# Altium schematic sheet 04-Accel.SchDoc
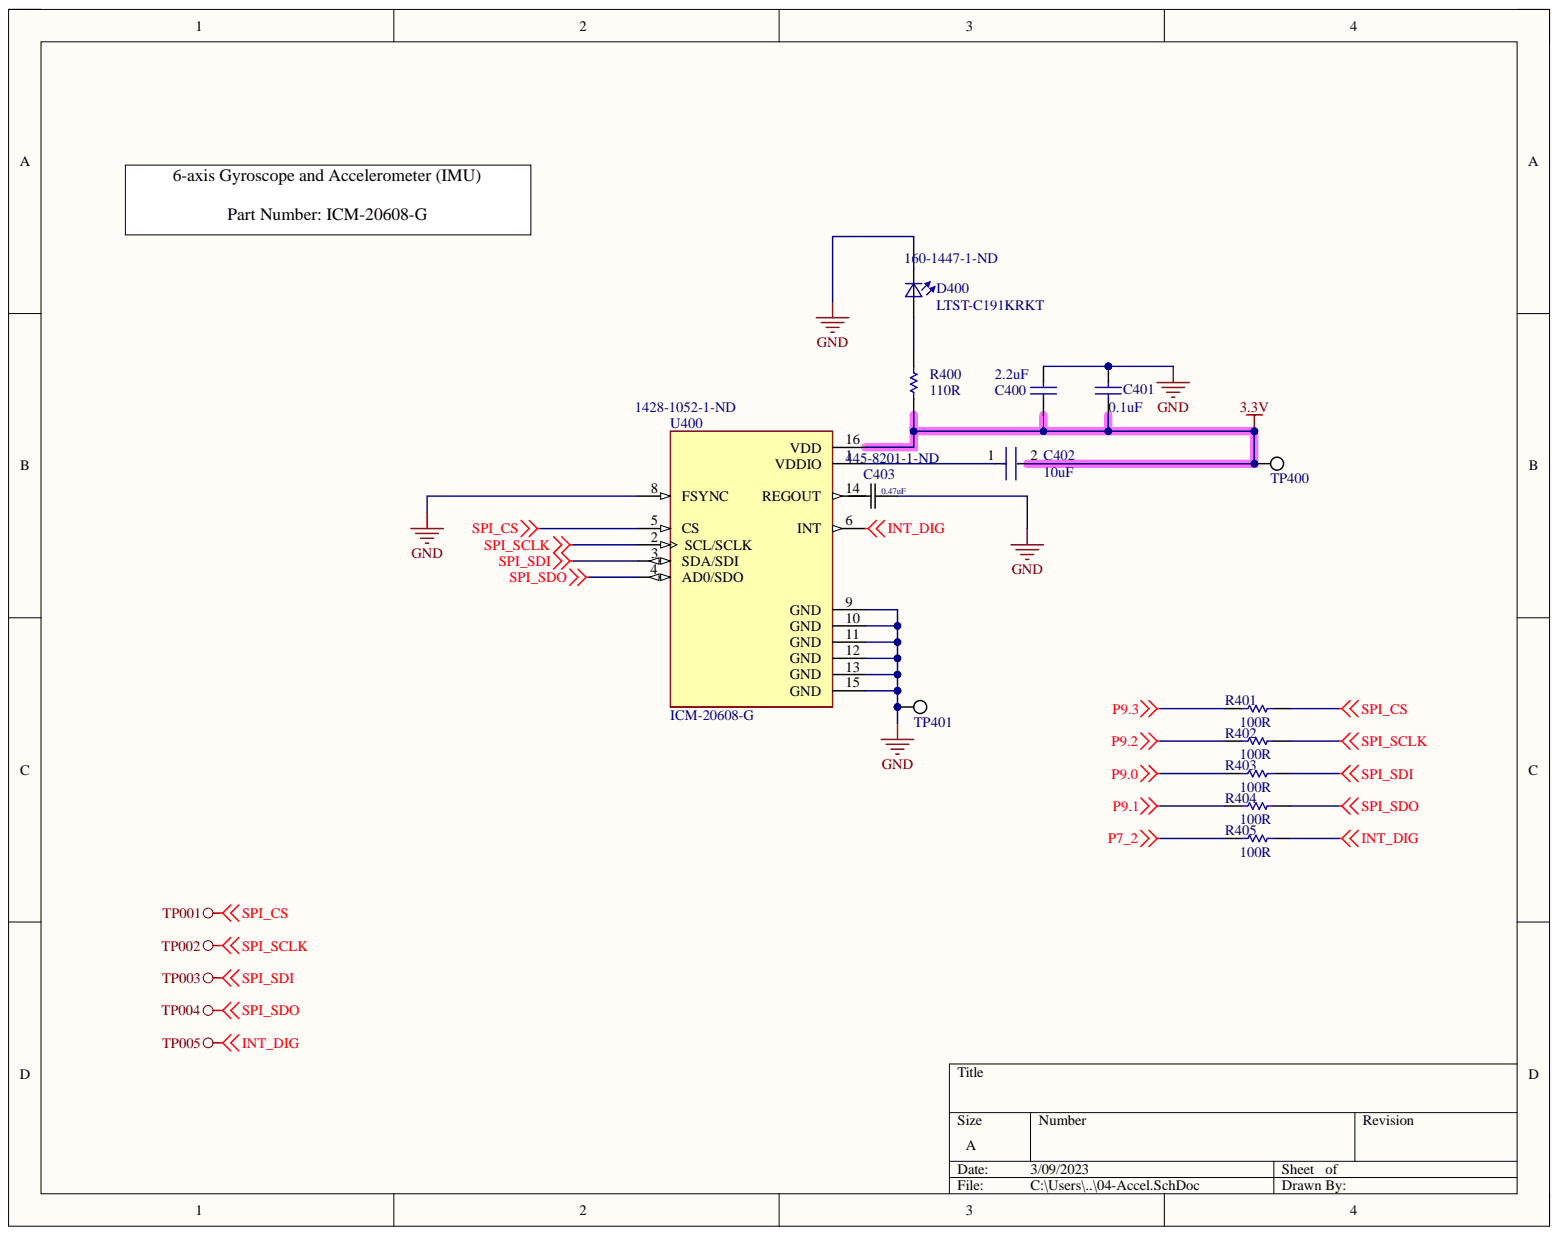
[diagram p0: 04-Accel.SchDoc]

## Parts
C400  2.2uF  [CAPC2013X145X50ML20T25]
C401  0.1uF  [CAPC2013X145X50LL20T25]
C402  10uF  [FP-C0805C-DN-MFG]
C403  0.47uF  Supplier Part Number 2: 445-8201-1-ND  [CAP_2012_TDK]
D400  LTST-C191KRKT  Supplier Part Number 1: 160-1447-1-ND  [0603]
R400  110R  [RESC2013X60X40NL20T25]
R401  100R  [RESC2013X60X40ML20T25]
R402  100R  [RESC2013X60X40ML20T25]
R403  100R  [RESC2013X60X40ML20T25]
R404  100R  [RESC2013X60X40ML20T25]
R405  100R  [RESC2013X60X40ML20T25]
TP400  5019  [KSTN-5019_V]  (value not drawn)
TP401  5019  [KSTN-5019_V]  (value not drawn)
U400  ICM-20608-G  Supplier Part Number 1: 1428-1052-1-ND  [PQFN50P300X300X80-16N]

## Nets
3.3V: C400.1 C401.1 C402.2 R400.1 TP400.1 U400.16(VDD)
GND: C400.2 C401.2 C403.2 D400.1 TP401.1 U400.8(FSYNC) U400.9(GND) U400.10(GND) U400.11(GND) U400.12(GND) U400.13(GND) U400.15(GND)
INT_DIG: R405.2 U400.6(INT)
P7_2: R405.1
P9.0: R403.1
P9.1: R404.1
P9.2: R402.1
P9.3: R401.1
SPI_CS: R401.2 U400.5(CS)
SPI_SCLK: R402.2 U400.2(SCL/SCLK)
SPI_SDI: R403.2 U400.3(SDA/SDI)
SPI_SDO: R404.2 U400.4(AD0/SDO)
NetC402_1: C402.1 U400.1(VDDIO)
NetD400_2: D400.2 R400.2

Not connected: C403 1; U400 14(REGOUT)

## Library data of the parts
C400 = CMP-1036-04819-2: Manufacturer: Kemet; Part Number: C0805C225K9PACTU; Component Kind: Standard; Library Name: Altium Content Vault
C401 = CMP-1036-04391-2: Manufacturer: Kemet; Part Number: C0805C104K9RACTU; Component Kind: Standard; Library Name: Altium Content Vault
C402 = CMP-1036-03808-3: CAP CER 10000PF 10V X7R 0805; Manufacturer: KEMET; Manufacturer Part Number: C0805C103K8RACTU; Capacitance: 10,000 pF; Material: Ceramic; Temperature Coefficient: 30ppm/°C; Tolerance: 0.1; Value: 10,000 pF; Automotive: No; Category: Cap; Component Kind: Standard; ComponentLink2Description: Datasheet; ComponentLink2URL: https://content.kemet.com/datasheets/KEM_C1003_C0G_SMD.pdf; Device Class L1: Passive Components; Device Class L2: Capacitors; Device Class L3: Ceramic Capacitors; Digikey Description: CAP CER 10000PF 10V X7R 0805; Height: 0.88mm; Ipc Land Pattern Name: CAPC200125X78; Lead Free: Yes; Library Name: Altium Content Vault; Mouser Description: Multilayer Ceramic Capacitors MLCC - SMD/SMT 10volts 10000pF X7R 10%; Octopart: https://octopart.com/c0805c103k8ractu-kemet-12595572; Package: C0805C-DN; RoHS: TRUE; Temperature: -55°C to +125°C; Temperature Characteristic: X7R; Temperature Range High: +125°C; Temperature Range Low: -55°C; Voltage Rating: 10 V
C403 = CGJ4J2X7R0J474K125AA: Cap Ceramic 0.47uF 6.3V X7R 10% Pad SMD 0805 125C Automotive T/R; Manufacturer: TDK; Capacitance: 470nF; Ratings: COTS; Case Code (Imperial): 0805; Case Code (Metric): 2012; Case/Package: 0805; Category: Ceramic Capacitors; Component Kind: Standard; ComponentLink1Description: https://datasheet.ciiva.com/15192/getdatasheetpartid-712880-15192524.pdf?src-supplier=Verical; ComponentLink1URL: https://datasheet.ciiva.com/15192/getdatasheetpartid-712880-15192524.pdf?src-supplier=Verical; ComponentLink2Description: https://datasheet.ciiva.com/24216/mlcc-highreliability-general-en-520187-24216918.pdf?src-supplier=Mouser; ComponentLink2URL: https://datasheet.ciiva.com/24216/mlcc-highreliability-general-en-520187-24216918.pdf?src-supplier=Mouser; Depth: 1.25mm; Dielectric: X7R; Height: 1.25mm; Length: 2mm; Library Name: CGJ4J2X7R0J474K125AA.SchLib; Manufacturer Part Number: CGJ4J2X7R0J474K125AA; Max Operating Temperature: 125°C; Mfr_Name: TDK; Min Operating Temperature: -55°C; Mount: Surface Mount; Package Quantity: 2000; Packaging: Tape and Reel; Pricing 2: 1=0.43000, 10=0.30400, 50=0.23020, 100=0.20040, 500=0.14848, 1000=0.12620 (USD); Radiation Hardening: No; Resistor Type: Ceramic; RoHS: Yes; Series: CGJ; Stock 2: 1790; Supplier 1: Digi-Key; Supplier 2: Digi-Key; Termination: SMD/SMT; Thickness: 0.053inch; Tolerance: 10%; Voltage Rating: 6.3V; Voltage Rating (DC): 6.3V; Weight: 0.000194oz; Width: 0.049inch
D400 = LTST-C191KRKT: LED Uni-Color Red 639nm 2-Pin Chip LED T/R / LED RED CLEAR 0603 SMD; Manufacturer: Vishay Lite-On; Dominant Wavelength: 631nm; Forward Current: 30mA; Forward Voltage: 2V; Lens Color: Colorless; Lens Style: Clear,Diffused,Colorless,Rectangular; Luminous Intensity: 54mcd; Number of Elements: 1; Power Dissipation: 75mW; Reverse Voltage: 5V; Test Current: 20mA; Viewing Angle: 130°; Availability: Unavailable; Case Code (Imperial): 0603; Case Code (Metric): 1608; Case/Package: 0603; Category: LEDs; Color: Red; Component Kind: Standard; ComponentLink1Description: https://datasheet.ciiva.com/12400/lite-on-ltst-c191krkt-190135-12400910.pdf?src-supplier=Mouser; ComponentLink1URL: https://datasheet.ciiva.com/12400/lite-on-ltst-c191krkt-190135-12400910.pdf?src-supplier=Mouser; ComponentLink2Description: https://datasheet.ciiva.com/16212/ltst-c191krkt-16212419.pdf?src-supplier=Future+Electronics; ComponentLink2URL: https://datasheet.ciiva.com/16212/ltst-c191krkt-16212419.pdf?src-supplier=Future+Electronics; ComponentLink3Description: https://datasheet.ciiva.com/15117/getdatasheetpartid-141922-15117737.pdf?src-supplier=Verical; ComponentLink3URL: https://datasheet.ciiva.com/15117/getdatasheetpartid-141922-15117737.pdf?src-supplier=Verical; ComponentLink4Description: https://datasheet.ciiva.com/11773/0900766b80dc02b2-11773601.pdf?src-supplier=Rs; ComponentLink4URL: https://datasheet.ciiva.com/11773/0900766b80dc02b2-11773601.pdf?src-supplier=Rs; ComponentLink5Description: https://datasheet.ciiva.com/2109/s-110-ltst-c191krkt-2109971.pdf?src-supplier=Digi-Key; ComponentLink5URL: https://datasheet.ciiva.com/2109/s-110-ltst-c191krkt-2109971.pdf?src-supplier=Digi-Key; ComponentLink6Description: https://datasheet.ciiva.com/2109/s-110-ltst-c191krkt-2109970.pdf?src-supplier=Digi-Key; ComponentLink6URL: https://datasheet.ciiva.com/2109/s-110-ltst-c191krkt-2109970.pdf?src-supplier=Digi-Key; ComponentLink7Description: https://datasheet.ciiva.com/2109/s-110-ltst-c191krkt-2109972.pdf?src-supplier=Digi-Key; ComponentLink7URL: https://datasheet.ciiva.com/2109/s-110-ltst-c191krkt-2109972.pdf?src-supplier=Digi-Key; Depth: 0.8mm; Height: 0.55mm; Illumination Color: Red; Lead Free: Lead Free; Length: 1.6mm; Lens Transparency: Clear; Library Name: LTST-C191KRKT.SchLib; Manufacturer Part Number: LTST-C191KRKT; Max Operating Temperature: 85°C; Min Operating Temperature: -55°C; Mount: Surface Mount; MP: LTST-C191KRKT; Number of LEDs: 1; Number of Pins: 2; Package: None; Package Quantity: 1; Packaging: Tape and Reel; Power Rating: 75mW; Price: None; Pricing 1: 1=0.26000, 10=0.14100, 100=0.06560, 500=0.06060, 1000=0.04747, 2000=0.04646 (USD); Radiation Hardening: No; RoHS: Yes; RoHS Compliant: Yes; Stock 1: 862758; Supplier 1: Digi-Key; Voltage: 2V; Wavelength: 639nm; Width: 0.8mm
R400 = CMP-2001-00585-1: Manufacturer: Panasonic; Part Number: ERA6AEB111V; Component Kind: Standard; Library Name: Altium Content Vault
R401-R405 = CMP-2001-00580-1: Manufacturer: Panasonic; Part Number: ERA6AEB101V; Component Kind: Standard; Library Name: Altium Content Vault
TP400 TP401 = CMP-1672-00008-1: Test Point, 1 Position SMD, RoHS, Tape and Reel; Manufacturer 1: Keystone Electronics; Category: Connectors; Component Kind: Standard; ComponentLink1Description: Manufacturer URL; ComponentLink1URL: http://www.keyelco.com/; ComponentLink2Description: Datasheet; ComponentLink2URL: http://www.keyelco.com/product-pdf.cfm?p=1357; ComponentLink3Description: https://datasheet.ciiva.com/16318/m60-2p61-16318395.pdf?src-supplier=Future+Electronics; ComponentLink3URL: https://datasheet.ciiva.com/16318/m60-2p61-16318395.pdf?src-supplier=Future+Electronics; ComponentLink4Description: https://datasheet.ciiva.com/16885/70182998-16885945.pdf?src-supplier=Allied+Electronics; ComponentLink4URL: https://datasheet.ciiva.com/16885/70182998-16885945.pdf?src-supplier=Allied+Electronics; ComponentLink5Description: https://datasheet.ciiva.com/14848/m60-2p61-14848437.pdf?src-supplier=Digi-Key; ComponentLink5URL: https://datasheet.ciiva.com/14848/m60-2p61-14848437.pdf?src-supplier=Digi-Key; ComponentLink6Description: https://datasheet.ciiva.com/14848/m60-2p61-14848438.pdf?src-supplier=Digi-Key; ComponentLink6URL: https://datasheet.ciiva.com/14848/m60-2p61-14848438.pdf?src-supplier=Digi-Key; ComponentLink7Description: https://datasheet.ciiva.com/14848/m60-2p61-14848439.pdf?src-supplier=Digi-Key; ComponentLink7URL: https://datasheet.ciiva.com/14848/m60-2p61-14848439.pdf?src-supplier=Digi-Key; Contact Material: Bronze; Contact Plating: Silver; DatasheetVersion: 03/2014; Height: 0.09inch; Lead Free: Lead Free; Library Name: Altium Content Vault; Manufacturer Part Number 1: 5019; Material: Bronze; Mount: Surface Mount; Mounting Technology: Surface Mount; Number of Pins: 1; Package Quantity: 1000; PackageDescription: 1-Pin Surface Mount Device, Body 3.81 x 2.03 mm; PackageReference: 5019; Packaging: Tape and Reel; Pins: 1; Pricing 1: 1=0.34000, 25=0.27360, 100=0.22340, 250=0.20064, 500=0.19152 (USD); REACH SVHC: No SVHC; RoHS: Yes; RoHS Compliant: Yes; RoHSCompliant: True; Series: 5019; Stock 1: 1316816; Supplier 1: Digi-Key; Supplier Part Number 1: 36-5019CT-ND; Termination: SMD/SMT
U400 = ICM-20608-G: MotionTracking Device Digital Output 1.8V 16-Pin LGA / IMU ACCEL/GYRO I2C/SPI 16LGA; Manufacturer: TDK InvenSense; Output Type: SPI,I2C,Digital; Sensor Type: Accelerometer,Gyroscope,3 Axis; Availability: Good; Case/Package: LGA; Category: Inertial Measurement Units (IMUs); Component Kind: Standard; ComponentLink1Description: https://www.invensense.com/wp-content/uploads/2015/03/ICM-20608-G-Product-Brief-v1.0.pdf?src-supplier=Digi-Key; ComponentLink1URL: https://www.invensense.com/wp-content/uploads/2015/03/ICM-20608-G-Product-Brief-v1.0.pdf?src-supplier=Digi-Key; Library Name: ICM-20608-G.IntLib; Manufacturer Part Number: ICM-20608-G; Max Operating Temperature: 85°C; MF: TDK InvenSense; Min Operating Temperature: -40°C; Mount: Surface Mount; MP: ICM-20608-G; Number of Pins: 16; Package: LGA-16 InvenSense; Price: None; Pricing 1: 1=7.78000, 5=6.86600, 10=6.06500, 25=4.92040, 50=4.34820, 100=4.23370, 500=3.54718, 1000=3.31832 (USD); Purchase-URL: https://pricing.snapeda.com/search/part/ICM-20608-G/?ref=eda; RoHS: No; RoHS Compliant: No; SnapEDA_Link: https://www.snapeda.com/parts/ICM-20608-G/TDK%20InvenSense/view-part/196551/?ref=snap; Stock 1: 18416; Supplier 1: Digi-Key
